# Altium schematic sheet 11 Connect.SchDoc
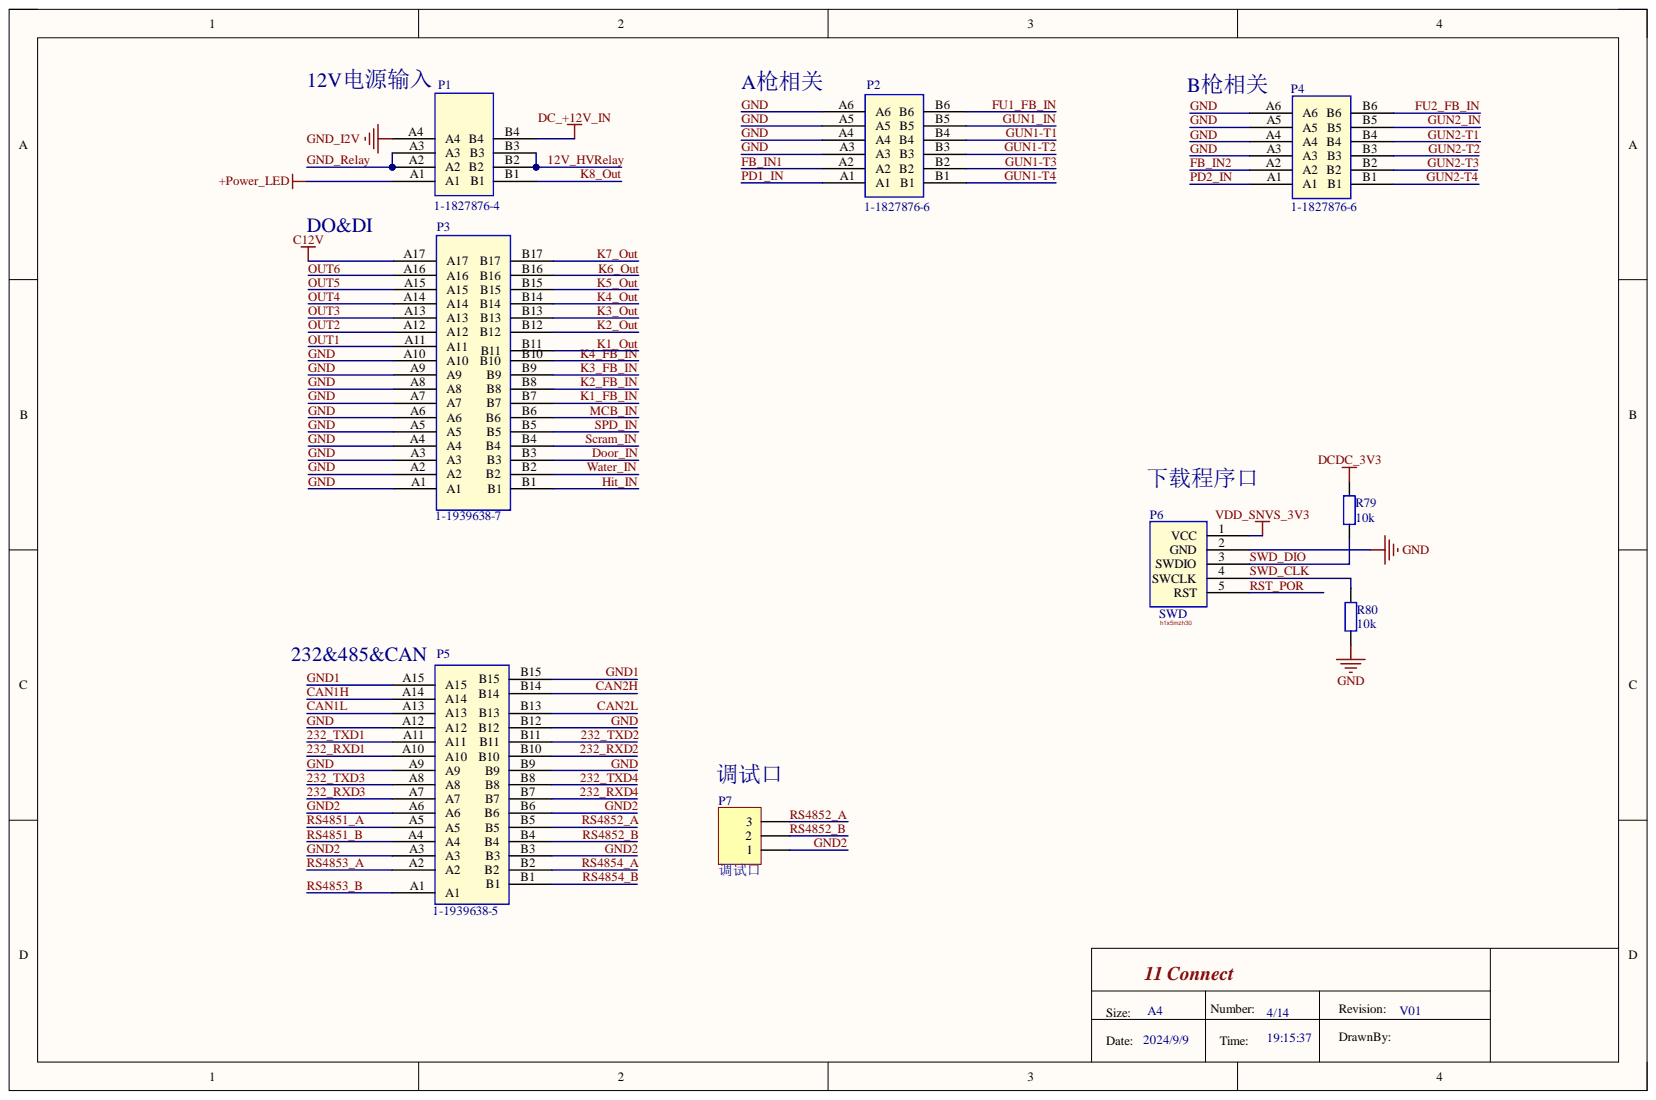
[diagram p0: 11 Connect.SchDoc]

## Parts
P1  1-1827876-4  [1-1827876-4]
P2  1-1827876-6  [1-1827876-6]
P3  1-1939638-7  [1-1939638-7]
P4  1-1827876-6  [1-1827876-6]
P5  1-1939638-5  [1-1939638-5]
P6  SWD  [h1x5mzh30]
P7  调试口  [H1X3MZH30]
R79  10k  [R0603]
R80  10k  [R0603]

## Nets
+Power_LED: P1.A1
C12V: P3.A17
DC_+12V_IN: P1.B4
DCDC_3V3: R79.1
GND_I2V: P1.A4
VDD_SNVS_3V3: P6.1(VCC)
12V_HVRelay: P1.B2 P1.B3
232_RXD1: P5.A10
232_RXD2: P5.B10
232_RXD3: P5.A7
232_RXD4: P5.B7
232_TXD1: P5.A11
232_TXD2: P5.B11
232_TXD3: P5.A8
232_TXD4: P5.B8
CAN1H: P5.A14
CAN1L: P5.A13
CAN2H: P5.B14
CAN2L: P5.B13
Door_IN: P3.B3
FB_IN1: P2.A2
FB_IN2: P4.A2
FU1_FB_IN: P2.B6
FU2_FB_IN: P4.B6
GND: P2.A3 P2.A4 P2.A5 P2.A6 P3.A1 P3.A2 P3.A3 P3.A4 P3.A5 P3.A6 P3.A7 P3.A8 P3.A9 P3.A10 P4.A3 P4.A4 P5.A9 P5.A12 P5.B9 P5.B12 P6.2(GND) R80.2
GND1: P5.A15 P5.B15
GND2: P5.A3 P5.A6 P5.B3 P5.B6 P7.1
GND_Relay: P1.A2 P1.A3
GUN1-T1: P2.B4
GUN1-T2: P2.B3
GUN1-T3: P2.B2
GUN1-T4: P2.B1
GUN1_IN: P2.B5
GUN2-T1: P4.B4
GUN2-T2: P4.B3
GUN2-T3: P4.B2
GUN2-T4: P4.B1
GUN2_IN: P4.B5
Hit_IN: P3.B1
K1_FB_IN: P3.B7
K1_Out: P3.B11
K2_FB_IN: P3.B8
K2_Out: P3.B12
K3_FB_IN: P3.B9
K3_Out: P3.B13
K4_FB_IN: P3.B10
K4_Out: P3.B14
K5_Out: P3.B15
K6_Out: P3.B16
K7_Out: P3.B17
K8_Out: P1.B1
MCB_IN: P3.B6
OUT1: P3.A11
OUT2: P3.A12
OUT3: P3.A13
OUT4: P3.A14
OUT5: P3.A15
OUT6: P3.A16
PD1_IN: P2.A1
PD2_IN: P4.A1
RS4851_A: P5.A5
RS4851_B: P5.A4
RS4852_A: P5.B5 P7.3
RS4852_B: P5.B4 P7.2
RS4853_A: P5.A2
RS4853_B: P5.A1
RS4854_A: P5.B2
RS4854_B: P5.B1
RST_POR: P6.5(RST)
Scram_IN: P3.B4
SPD_IN: P3.B5
SWD_CLK: P6.4(SWCLK) R80.1
SWD_DIO: P6.3(SWDIO) R79.2
Water_IN: P3.B2

Not connected: P4 A5 A6

## Library data of the parts
P1 P2 P4 = PPINJIAO_93: Component Kind: Standard
P3 = PPINJIAO_98: Component Kind: Standard
P5 = PPINJIAO_86: Component Kind: Standard
P6 = HEADER 3X2_3: Component Kind: Standard; Library Name: 原理图库.SCHLIB; PCB Footprint: h1x5mzh30
P7 = Header 3: Header, 3-Pin; 厂商: 红星; 厂商编号: HX25403-3A; Component Kind: Standard; LatestRevisionDate: 17-Jul-2002; LatestRevisionNote: Re-released for DXP Platform.; Library Name: Miscellaneous Connectors.IntLib; Signal Integrity: Connector; Publisher: Altium Limited
R79 R80 = Res: 电阻器; 厂: Samsung; Component Kind: Standard; Library Name: 超超的封装库.IntLib
